# Altium schematic sheet LCD.SchDoc(LCD)
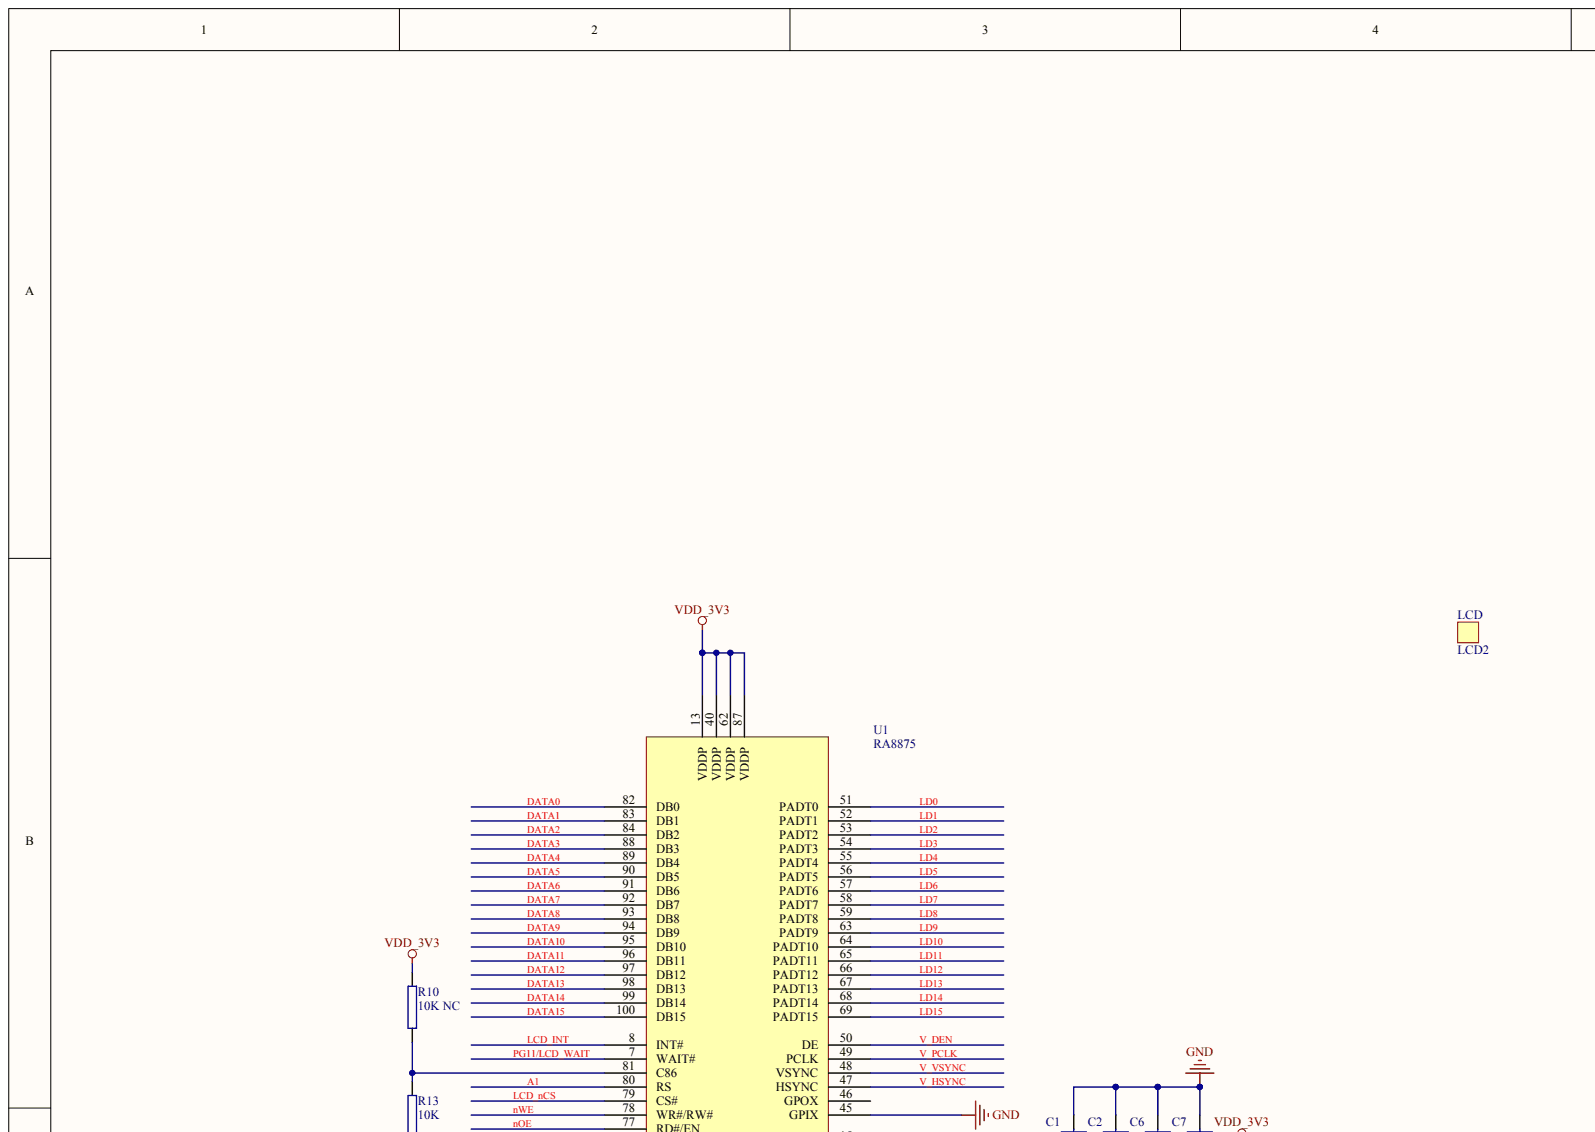
[diagram p0: LCD.SchDoc, top left]
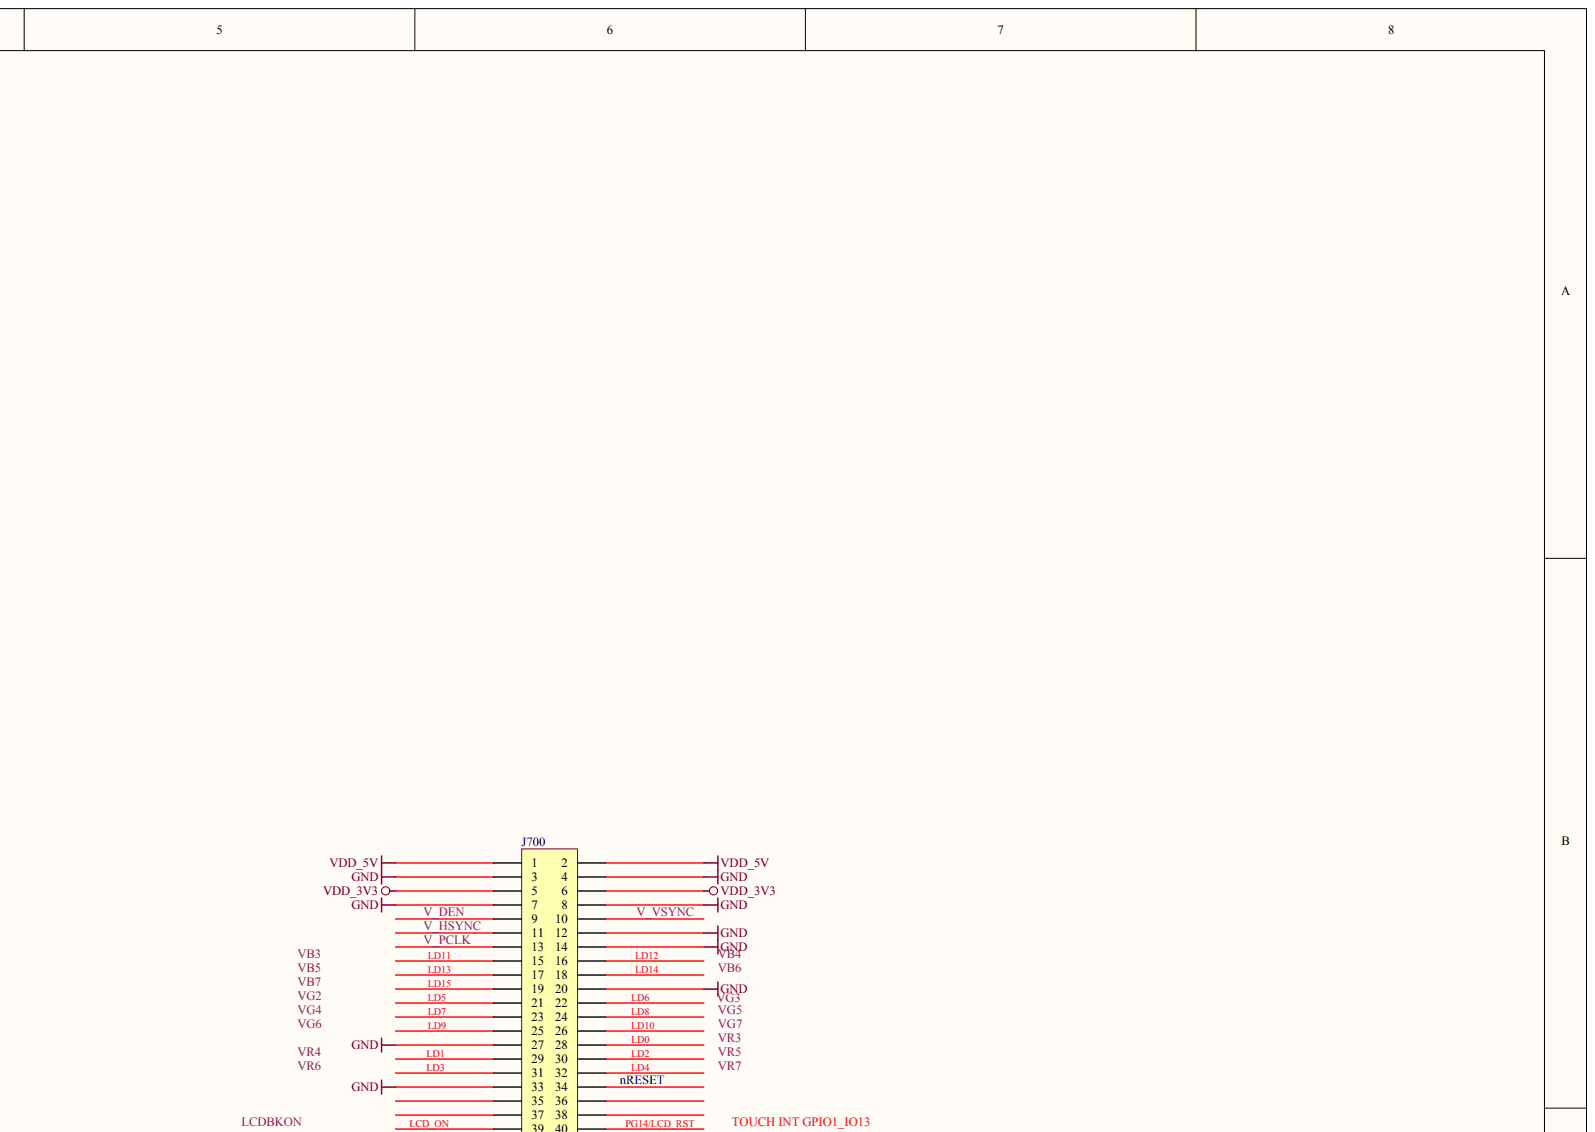
[diagram p1: LCD.SchDoc, top right]
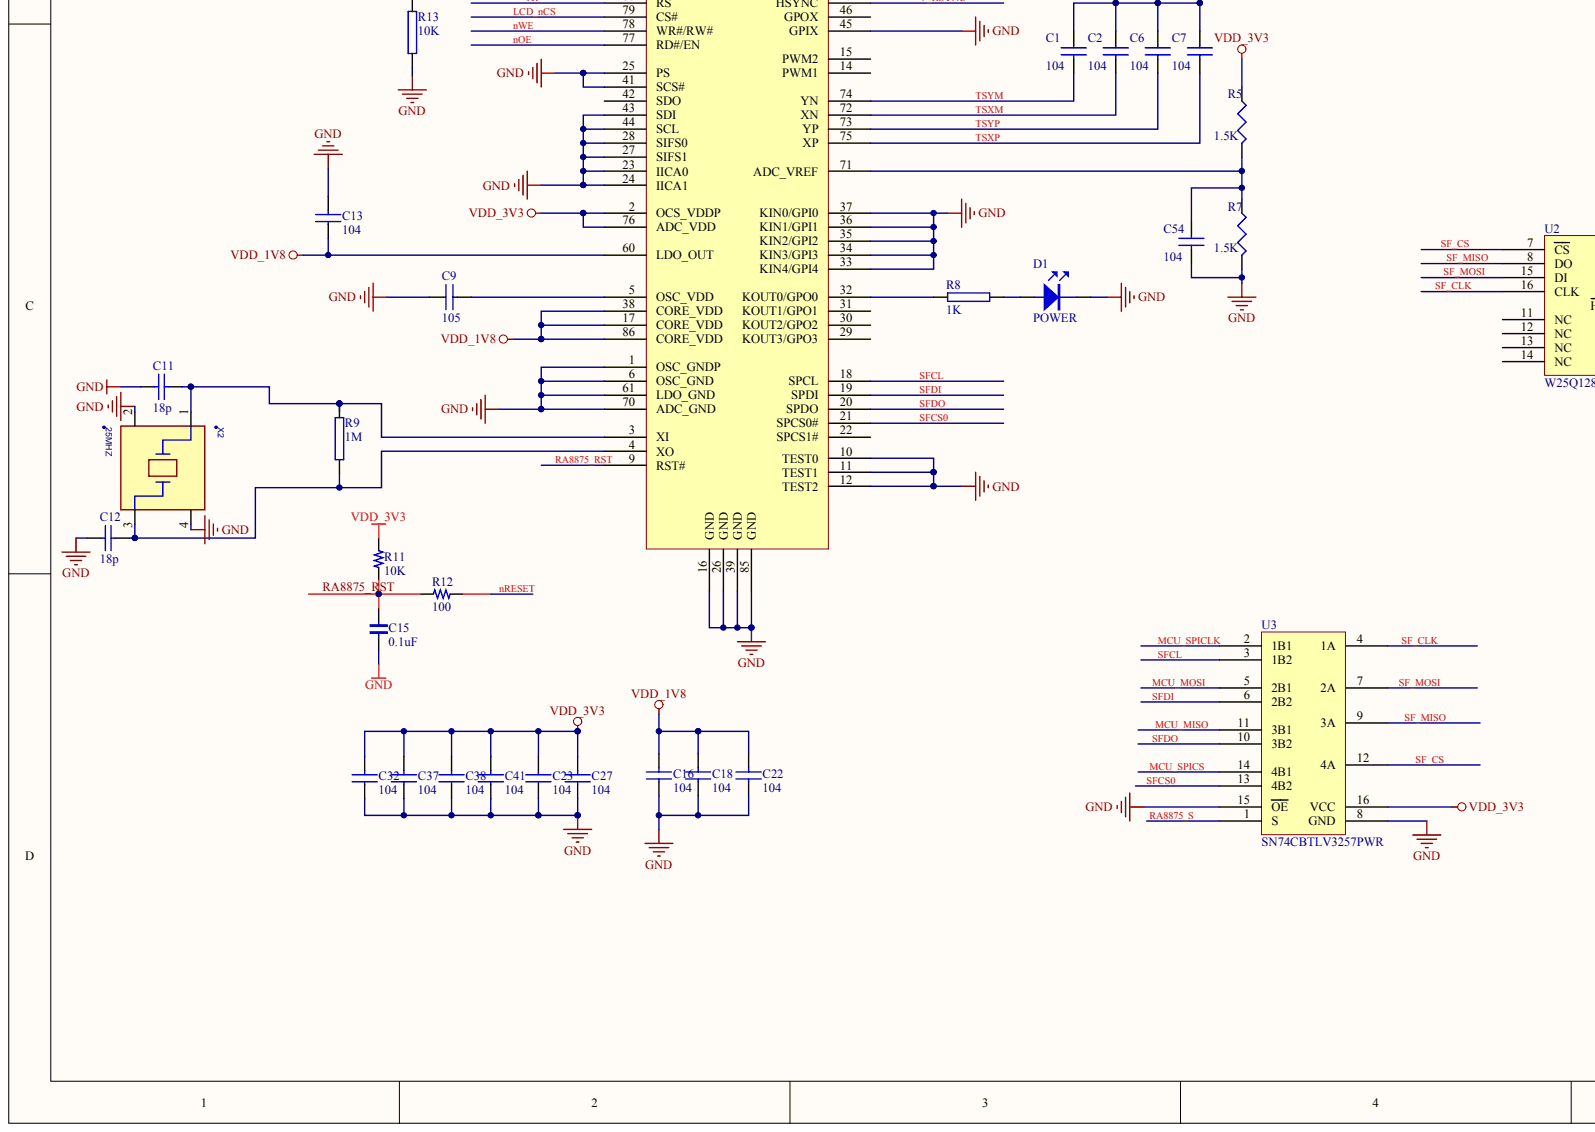
[diagram p2: LCD.SchDoc, bottom left]
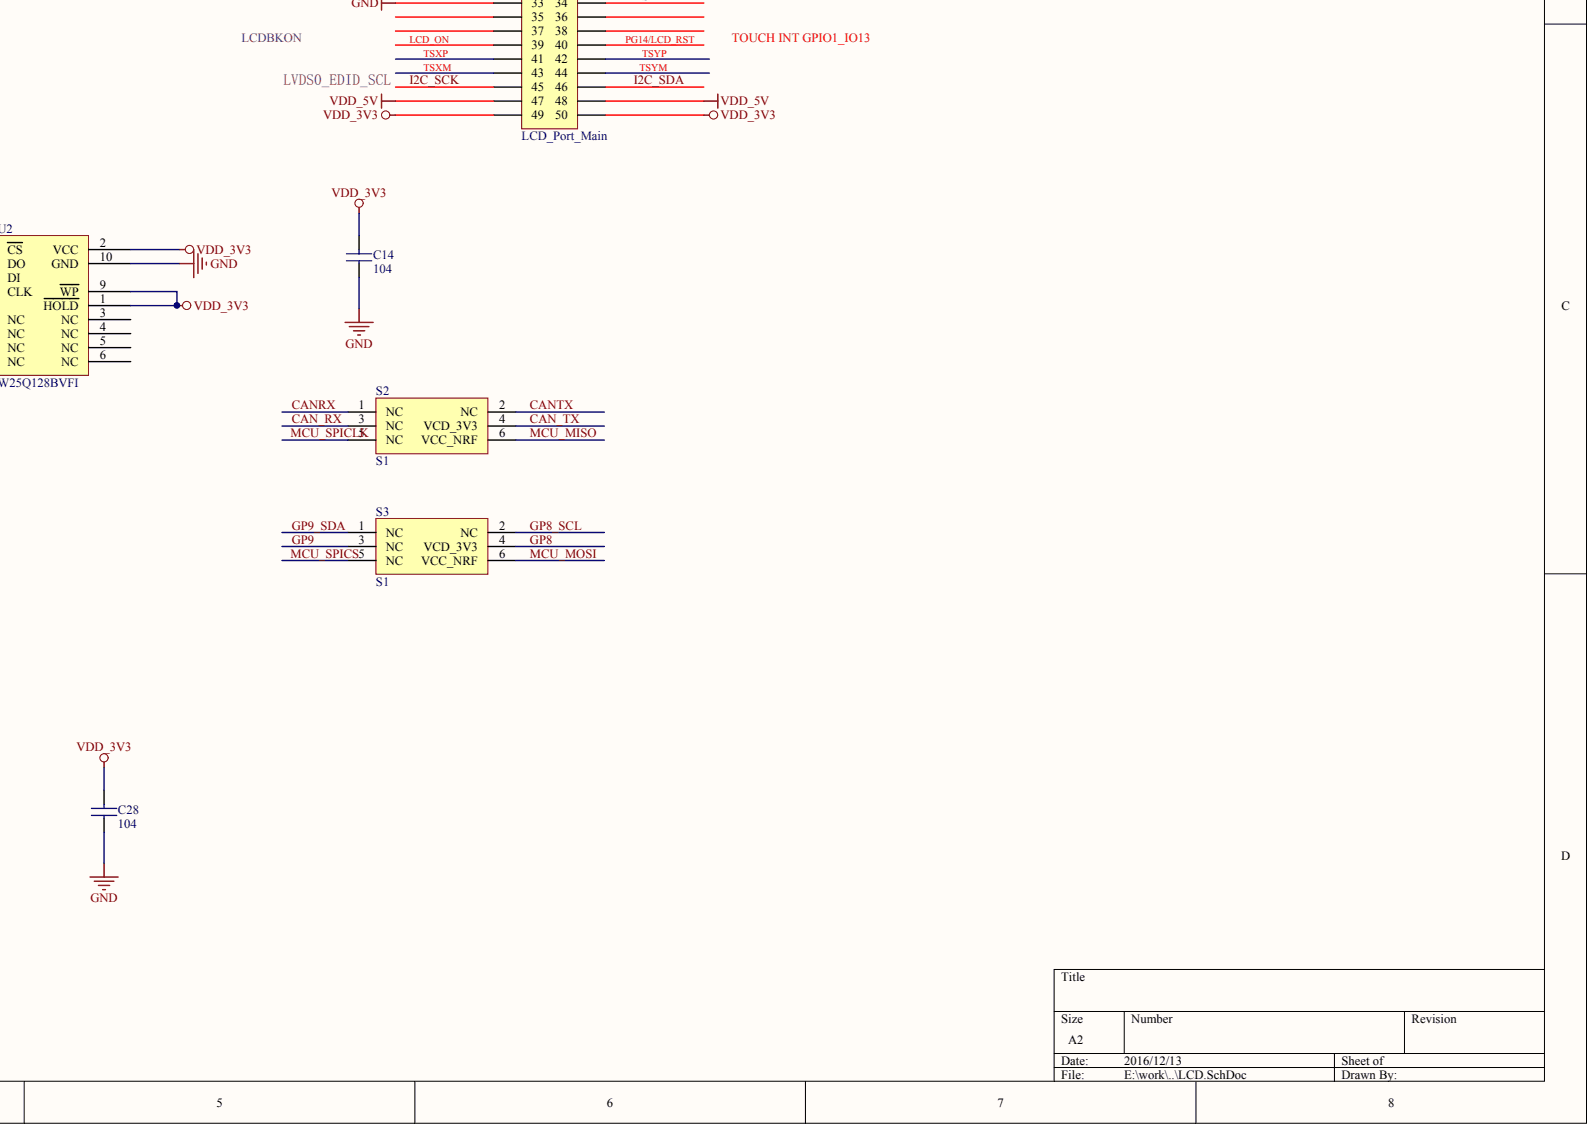
[diagram p3: LCD.SchDoc, bottom right]

## Parts
C1  104
C2  104
C6  104
C7  104
C9  105
C11  18p
C12  18p
C13  104
C14  104
C15  0.1uF
C16  104
C18  104
C22  104
C23  104
C27  104
C28  104
C32  104
C37  104
C38  104
C41  104
C54  104
D1  POWER
J700  LCD_Port_Main
LCD  LCD2
R5  1.5K
R7  1.5K
R8  1K
R9  1M
R10  10K NC
R11  10K
R12  100
R13  10K
S2  S1
S3  S1
U1  RA8875
U2  W25Q128BVFI
U3  SN74CBTLV3257PWR
X2  25MHZ

## Nets
GND: C1.1 C2.1 C6.1 C7.1 C9.1 C11.2 C12.2 C13.2 C14.1 C15.1 C16.1 C18.1 C22.1 C23.1 C27.1 C28.1 C32.1 C37.1 C38.1 C41.1 C54.2 D1.2 J700.3 J700.4 J700.7 J700.8 J700.12 J700.14 J700.20 J700.27 J700.33 R7.1 R13.1 U1.1(OSC_GNDP) U1.6(OSC_GND) U1.10(TEST0) U1.11(TEST1) U1.12(TEST2) U1.16(GND) U1.23(IICA0) U1.24(IICA1) U1.25(PS) U1.26(GND) U1.27(SIFS1) U1.28(SIFS0) U1.33(KIN4/GPI4) U1.34(KIN3/GPI3) U1.35(KIN2/GPI2) U1.36(KIN1/GPI1) U1.37(KIN0/GPI0) U1.39(GND) U1.41(SCS#) U1.43(SDI) U1.44(SCL) U1.45(GPIX) U1.61(LDO_GND) U1.70(ADC_GND) U1.85(GND) U2.10(GND) U3.8(GND) U3.15(OE) X2.2 X2.4
VDD_1V8: C13.1 C16.2 C18.2 C22.2 U1.17(CORE_VDD) U1.38(CORE_VDD) U1.60(LDO_OUT) U1.86(CORE_VDD)
VDD_3V3: C14.2 C23.2 C27.2 C28.2 C32.2 C37.2 C38.2 C41.2 J700.5 J700.6 J700.49 J700.50 R5.2 R10.2 R11.2 U1.2(OCS_VDDP) U1.13(VDDP) U1.40(VDDP) U1.62(VDDP) U1.76(ADC_VDD) U1.87(VDDP) U2.1(HOLD) U2.2(VCC) U2.9(WP) U3.16(VCC)
VDD_5V: J700.1 J700.2 J700.47 J700.48
A1: U1.80(RS)
CAN_RX: S2.3(NC)
CAN_TX: S2.4(VCD_3V3)
CANRX: S2.1(NC)
CANTX: S2.2(NC)
DATA0: U1.82(DB0)
DATA1: U1.83(DB1)
DATA2: U1.84(DB2)
DATA3: U1.88(DB3)
DATA4: U1.89(DB4)
DATA5: U1.90(DB5)
DATA6: U1.91(DB6)
DATA7: U1.92(DB7)
DATA8: U1.93(DB8)
DATA9: U1.94(DB9)
DATA10: U1.95(DB10)
DATA11: U1.96(DB11)
DATA12: U1.97(DB12)
DATA13: U1.98(DB13)
DATA14: U1.99(DB14)
DATA15: U1.100(DB15)
GP8: S3.4(VCD_3V3)
GP8_SCL: S3.2(NC)
GP9: S3.3(NC)
GP9_SDA: S3.1(NC)
I2C_SCK: J700.45
I2C_SDA: J700.46
LCD_INT: U1.8(INT#)
LCD_NCS: U1.79(CS#)
LCD_ON: J700.39
LD0: J700.28 U1.51(PADT0)
LD1: J700.29 U1.52(PADT1)
LD2: J700.30 U1.53(PADT2)
LD3: J700.31 U1.54(PADT3)
LD4: J700.32 U1.55(PADT4)
LD5: J700.21 U1.56(PADT5)
LD6: J700.22 U1.57(PADT6)
LD7: J700.23 U1.58(PADT7)
LD8: J700.24 U1.59(PADT8)
LD9: J700.25 U1.63(PADT9)
LD10: J700.26 U1.64(PADT10)
LD11: J700.15 U1.65(PADT11)
LD12: J700.16 U1.66(PADT12)
LD13: J700.17 U1.67(PADT13)
LD14: J700.18 U1.68(PADT14)
LD15: J700.19 U1.69(PADT15)
MCU_MISO: S2.6(VCC_NRF) U3.11(3B1)
MCU_MOSI: S3.6(VCC_NRF) U3.5(2B1)
MCU_SPICLK: S2.5(NC) U3.2(1B1)
MCU_SPICS: S3.5(NC) U3.14(4B1)
NOE: U1.77(RD#/EN)
NRESET: J700.34 R12.1
NWE: U1.78(WR#/RW#)
PG11/LCD_WAIT: U1.7(WAIT#)
PG14/LCD_RST: J700.40
RA8875_RST: C15.2 R11.1 R12.2 U1.9(RST#)
RA8875_S: U3.1(S)
SF_CLK: U2.16(CLK) U3.4(1A)
SF_CS: U2.7(CS) U3.12(4A)
SF_MISO: U2.8(DO) U3.9(3A)
SF_MOSI: U2.15(DI) U3.7(2A)
SFCL: U1.18(SPCL) U3.3(1B2)
SFCS0: U1.21(SPCS0#) U3.13(4B2)
SFDI: U1.19(SPDI) U3.6(2B2)
SFDO: U1.20(SPDO) U3.10(3B2)
TSXM: C2.2 J700.43 U1.72(XN)
TSXP: C7.2 J700.41 U1.75(XP)
TSYM: C1.2 J700.44 U1.74(YN)
TSYP: C6.2 J700.42 U1.73(YP)
V_DEN: J700.9 U1.50(DE)
V_HSYNC: J700.11 U1.47(HSYNC)
V_PCLK: J700.13 U1.49(PCLK)
V_VSYNC: J700.10 U1.48(VSYNC)
NetC9_2: C9.2 U1.5(OSC_VDD)
NetC11_1: C11.1 R9.2 U1.3(XI) X2.1
NetC12_1: C12.1 R9.1 U1.4(XO) X2.3
NetC54_1: C54.1 R5.1 R7.2 U1.71(ADC_VREF)
NetD1_1: D1.1 R8.2
NetR8_1: R8.1 U1.32(KOUT0/GPO0)
NetR10_1: R10.1 R13.2 U1.81(C86)

Not connected: J700 35 36 37 38; U1 14(PWM1) 15(PWM2) 22(SPCS1#) 29(KOUT3/GPO3) 30(KOUT2/GPO2) 31(KOUT1/GPO1) 42(SDO) 46(GPOX); U2 3(NC) 4(NC) 5(NC) 6(NC) 11(NC) 12(NC) 13(NC) 14(NC)

## Library data of the parts
R5 R7 = (no symbol name): C67: K7
X2 = (no symbol name): CLASS: IC; CAD_APPROVED: Yes; MFG_PN01: ABMM-25.000MHZ-B2-T; MFG_NAME01: ABRACON CORP; AGILE_PN: 230-77853; HEIGHT: 1.3MM; ROHS: Yes
C1 C2 C6 C7 C9 C11-C16 C18 C22 C23 C27 C28 C32 C37 C38 C41 C54 D1 J700 LCD R8-R13 S2 S3 U1-U3 = (no symbol name)
  J700: LatestRevisionDate: 17-Jul-2002; LatestRevisionNote: Re-released for DXP Platform.; Publisher: Altium Limited
